# Altium schematic sheet SteppperController.SchDoc
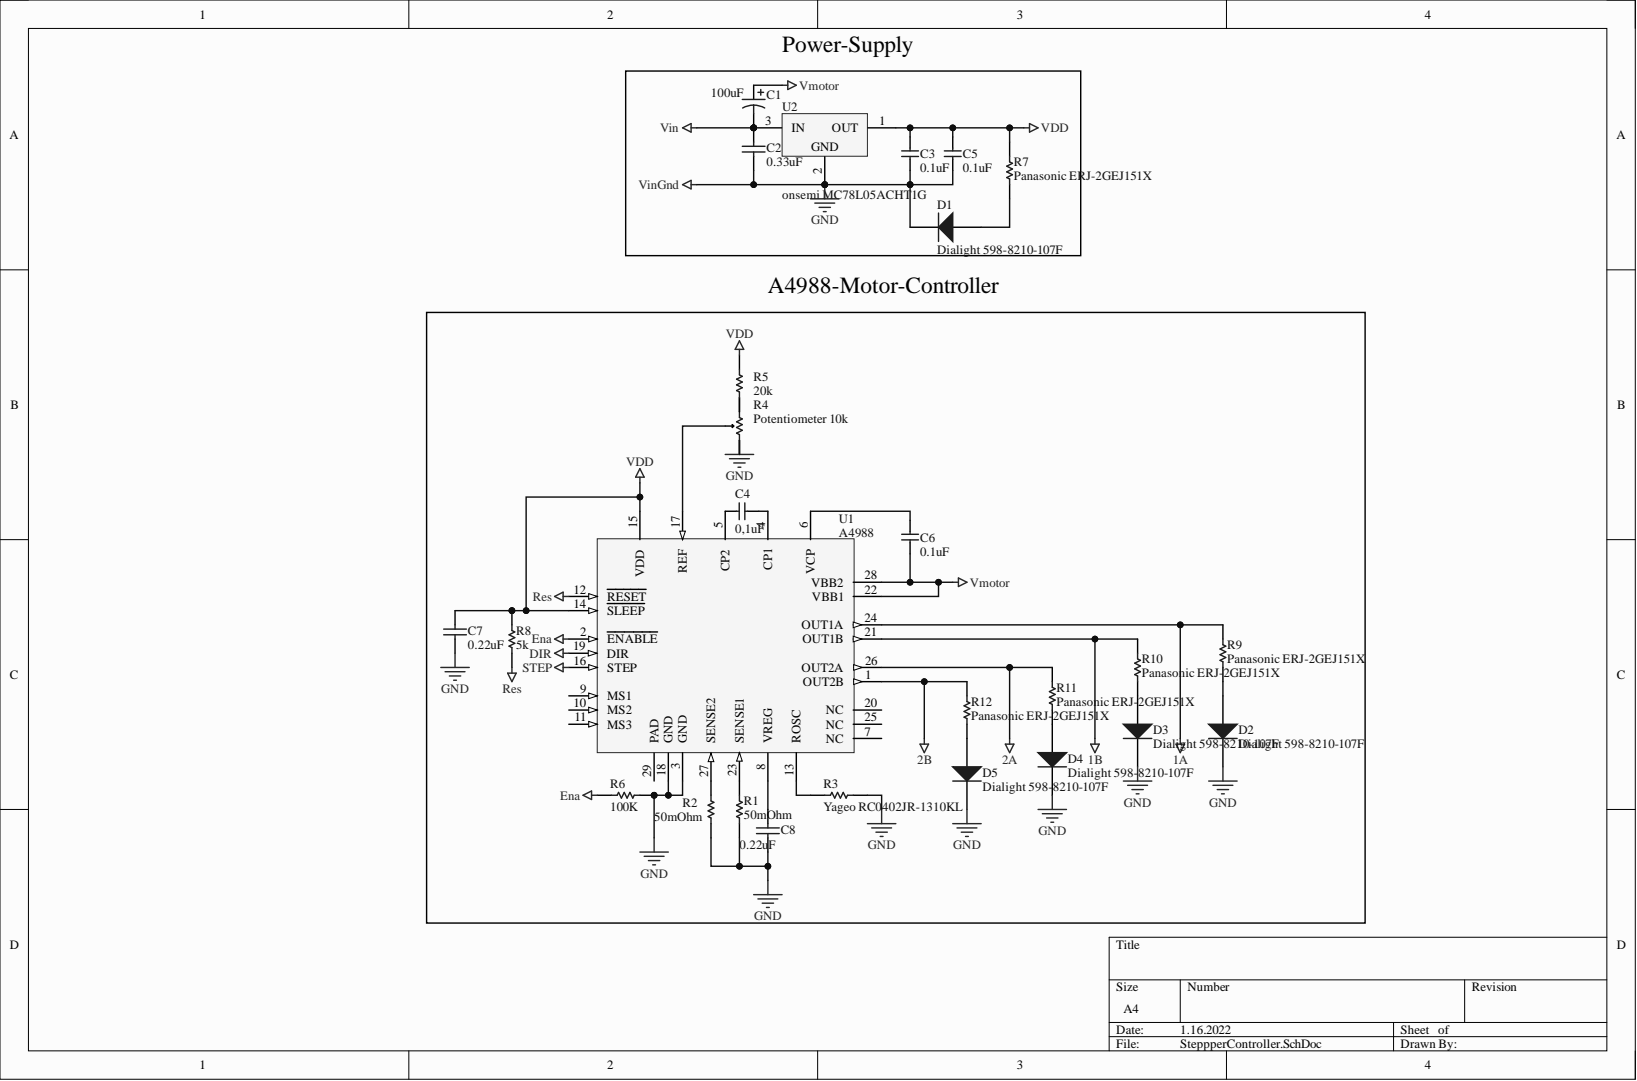
[diagram p0: SteppperController.SchDoc]

## Parts
C1  100uF  [PCB-i2lbf4zom8ks3vbq1kyd-1]
C2  0.33uF  [PCB-w610zufupuummbghd1in-1]
C3  0.1uF  [PCB-lcc7kuvv5qjd2xw4yurg-1]
C4  0,1uF  [PCB-lcc7kuvv5qjd2xw4yurg-1]
C5  0.1uF  [PCB-lcc7kuvv5qjd2xw4yurg-1]
C6  0.1uF  [PCB-lcc7kuvv5qjd2xw4yurg-1]
C7  0.22uF  [PCB-pms7qjbv2zfto0v29362-1]
C8  0.22uF  [PCB-pms7qjbv2zfto0v29362-1]
D1  Dialight 598-8210-107F  Manufacturer Part Number: 598-8210-107F  [PCB-nfeeyiqhjphw6gveeqew-1]
D2  Dialight 598-8210-107F  Manufacturer Part Number: 598-8210-107F  [PCB-nfeeyiqhjphw6gveeqew-1]
D3  Dialight 598-8210-107F  Manufacturer Part Number: 598-8210-107F  [PCB-nfeeyiqhjphw6gveeqew-1]
D4  Dialight 598-8210-107F  Manufacturer Part Number: 598-8210-107F  [PCB-nfeeyiqhjphw6gveeqew-1]
D5  Dialight 598-8210-107F  [PCB-nfeeyiqhjphw6gveeqew-1]
R1  50mOhm  [PCB-h38ewjcsmg51leo397rp-1]
R2  50mOhm  [PCB-h38ewjcsmg51leo397rp-1]
R3  Yageo RC0402JR-1310KL  Manufacturer Part Number: RC0402JR-1310KL  [RESC1005X40X25LL05T10]
R4  Potentiometer 10k  [PCB-obt52c5d0m95txgvi4qh-1]
R5  20k  [RESC2013X55X40LL15T20]
R6  100K  [PCB-n97kkldbbf5rklmnt71m-1]
R7  Panasonic ERJ-2GEJ151X  [RESC1005X40X25ML05T05]
R8  5k  [RESC1608X55X30NL20T20]
R9  Panasonic ERJ-2GEJ151X  Manufacturer Part Number: ERJ-2GEJ151X  [RESC1005X40X25ML05T05]
R10  Panasonic ERJ-2GEJ151X  Manufacturer Part Number: ERJ-2GEJ151X  [RESC1005X40X25ML05T05]
R11  Panasonic ERJ-2GEJ151X  Manufacturer Part Number: ERJ-2GEJ151X  [RESC1005X40X25ML05T05]
R12  Panasonic ERJ-2GEJ151X  [RESC1005X40X25ML05T05]
U1  A4988  [ALEG-ET-28_V]
U2  onsemi MC78L05ACHT1G  Manufacturer Part Number: MC78L05ACHT1G  [NXP-SOT89_A_M]

## Nets
1A: R9.2 U1.24(OUT1A)
1B: R10.2 U1.21(OUT1B)
2A: R11.2 U1.26(OUT2A)
2B: R12.2 U1.1(OUT2B)
DIR: U1.19(DIR)
Ena: R6.2 U1.2(ENABLE)
GND: C2.1 C3.1 C5.1 C7.1 C8.1 D1.2 D2.2 D3.2 D4.2 D5.2 R1.1 R2.1 R3.2 R4.3 R6.1 U1.3(GND) U1.18(GND) U2.2
Res: R8.1 U1.12(RESET)
STEP: U1.16(STEP)
VDD: C3.2 C5.2 C7.2 R5.2 R7.2 R8.2 U1.14(SLEEP) U1.15(VDD) U2.1
Vin: C1.2 C2.2 U2.3
Vmotor: C1.1 C6.1 U1.22(VBB1) U1.28(VBB2)
NetC4_1: C4.1 U1.5(CP2)
NetC4_2: C4.2 U1.4(CP1)
NetC6_2: C6.2 U1.6(VCP)
NetC8_2: C8.2 U1.8(VREG)
NetD1_1: D1.1 R7.1
NetD2_1: D2.1 R9.1
NetD3_1: D3.1 R10.1
NetD4_1: D4.1 R11.1
NetD5_1: D5.1 R12.1
NetR1_2: R1.2 U1.23(SENSE1)
NetR2_2: R2.2 U1.27(SENSE2)
NetR3_1: R3.1 U1.13(ROSC)
NetR4_1: R4.1 R5.1
NetR4_2: R4.2 U1.17(REF)

Not connected: U1 7(NC) 9(MS1) 10(MS2) 11(MS3) 20(NC) 25(NC) 29(PAD)

## Library data of the parts
C1 = CMP-3b00f20907dbe4c7-1: 100uF 25 V dc Aluminium Electrolytic Capacitor; WT Series 1000h 6.3(Dia.)x7.7mm; Manufacturer Part Number: UWT1E101MCL1GS; Capacitance: 100 µF; Capacitance Tolerance: ±20%; Dielectric Material: Aluminum Electrolytic; Lead-Free Status: Lead Free; Voltage Rating (DC): 25.0 V; Component Kind: Standard; Library Name: My Personal Space; Mounting Style: Surface Mount; Number of Pins: 2; Octopart URL: https://octopart.com/uwt1e101mcl1gs-nichicon-203197; OctopartID: 3b00f20907dbe4c7; Operating Temperature: -55.0 °C (min); Packaging: Tape & Reel (TR), Cut Tape (CT); RoHS: Compliant; Size-Diameter: 6.30 mm; Size-Height: 7.70 mm; Size-Length: 7.70 mm
C2 = CMP-6acba34cbf0cb50c-1: CL31 Series 0.33 uF 50 V ±10 % Tolerance X7R SMT Multilayer Ceramic Capacitor; Manufacturer Part Number: CL31B334KBFNNNE; Capacitance: 330 nF; Capacitance Tolerance: ±10%; Lead-Free Status: Lead Free; Voltage Rating (DC): 50.0 V; Case/Package: 1206; Component Kind: Standard; Dielectric Characteristic: X7R; Library Name: My Personal Space; Mounting Style: Surface Mount; Octopart URL: https://octopart.com/cl31b334kbfnnne-samsung-9302177; OctopartID: 6acba34cbf0cb50c; RoHS: Compliant
C3-C6 = CMP-915a59dc44ec4e9d-4: C Series 1206 0.1 uF 50 V ±5 % Tolerance C0G SMT Multilayer Ceramic Capacitor; Component Kind: Standard; Library Name: My Personal Space
C7 C8 = CMP-3551434-4: Multilayer Ceramic Capacitors MLCC - SMD/SMT 50volts .22uF 5% X7R 7inch reel; Manufacturer Part Number: 08055C224JAT2A; Capacitance: 2.2e-07 F; Lead-Free Status: Lead Free; Voltage Rating (DC): 50.0 V; Capacitance Tolerance: ±5%; Case/Package: 0805; Component Kind: Standard; Dielectric Characteristic: X7R; Library Name: My Personal Space; Mounting Style: Surface Mount; Number of Pins: 2; Octopart Url: https://octopart.com/08055c224jat2a-avx+interconnect+%2F+elco-8665279; OctopartID: 61bba8357c62be7c; RoHS: Compliant
D1-D5 = CMP-bfda76ddda44601d-2: LED Uni-Color Red 2-Pin Chip 1206(3216Metric) T/R; Case/Package (SI): 3216; Forward Voltage: 2.00 V; Lead-Free Status: Lead Free; Lens Type: Non-Diffused; Luminous Intensity: 80.0 mcd; Viewing Angle: 140 °; Wavelength: 635 nm, 640 nm; Case/Package: 1206; Color: Red; Component Kind: Standard; Lens Color: Red; Library Name: My Personal Space; Mounting Style: Surface Mount, Vertical; Number of Pins: 2; Octopart URL: https://octopart.com/598-8210-107f-dialight-868600; OctopartID: bfda76ddda44601d; Operating Temperature: 85.0 °C (max); Packaging: Cut Tape (CT); REACH SVHC Compliance: No SVHC; RoHS: Compliant; Size-Height: 700 µm; Size-Length: 3.20 mm; Size-Width: 1.40 mm
  D5: Manufacturer Part Number: 598-8210-107F
R1 R2 = CMP-2fd8e4750972f01f-1: Thick Film Surface Mnt Resistor 2512 Case 50MOhm +/-1% 1W +/-100ppm/degC; Manufacturer Part Number: CRHV2512AF50M0FKET; Component Kind: Standard; Library Name: My Personal Space; Octopart URL: http://octopart.com/crhv2512af50m0fket-vishay-44499916; OctopartID: 2fd8e4750972f01f; Packaging: Reel
R3 = CMP-3759243-2: YAGEO   RC0402JR-1310KL   THICK FILM RESISTOR, 10KOHM, 62.5mW, 5%; Power Rating: 0.0625 W; Resistance: 10000.0 Ω; Voltage Rating (DC): 50.0 V; Case/Package: 0402; Component Kind: Standard; Library Name: My Personal Space; Mounting Style: Surface Mount; Number of Pins: 2; Octopart Url: https://octopart.com/rc0402jr-1310kl-yageo-12819538; OctopartID: 7a6eb3a7c35aa5a9; Packaging: Cut Tape (CT); Resistance Tolerance: ±5%; RoHS: Compliant; Size-Length: 0.001 m
R4 = CMP-1577701-10: Res Conductive Plastic POT 10K Ohm 20% 3/100W 1Turn 6mm Pin Bracket Mount/Through Hole; Manufacturer Part Number: P090L-02F25BR10K; Lead-Free Status: Lead Free; Power Rating: 30.0 mW; Component Kind: Standard; Library Name: My Personal Space; Mounting Style: Through Hole, Board, PCB; Octopart URL: https://octopart.com/p090l-02f25br10k-bi+technologies-5427413; OctopartID: 7c74b52c89c7a3b3; Operating Temperature: 70.0 °C (max); Packaging: Tray; Resistance Tolerance: ±20%; RoHS: Compliant
R5 = CMP-15323555-2: Manufacturer Part Number: TNPW080520K0BEEA; Lead-Free Status: Lead Free; Power Rating: 0.125 W; Resistance: 20000.0 Ω; Resistance Tolerance: ±0.1%; Voltage Rating (DC): 100.0 V; Case/Package: 0805; Component Kind: Standard; Library Name: My Personal Space; Number of Pins: 2; Octopart Url: https://octopart.com/tnpw080520k0beea-vishay-46507859; OctopartID: 596b92a4db5e86ac; RoHS: Compliant
R6 = CMP-86ed4a8b7141592a-1: Res General Purpose Thick Film 0402 100k Ohm 1% 1/16W ±100ppm/°C Molded Paper T/R; Manufacturer Part Number: CRGCQ0402F100K; Power Rating: 63.0 mW; Resistance: 100 kΩ; Temperature Coefficient: -100 ppm/°C to 100 ppm/°C; Voltage Rating (DC): 50.0 V; Case/Package: 0402; Component Kind: Standard; Library Name: My Personal Space; Lifecycle Status: Not Listed by Manufacturer; Octopart URL: https://octopart.com/crgcq0402f100k-te+connectivity-91017930; OctopartID: 86ed4a8b7141592a; Packaging: Tape & Reel (TR); Resistance Tolerance: ±1%; RoHS: Compliant
R7 R9-R12 = CMP-3653280-2: Thick Film Resistors - SMD 0402 150ohms 5% Tol; Lead-Free Status: Lead Free; Power Rating: 0.1 W; Resistance: 150.0 Ω; Voltage Rating (DC): 50.0 V; Case/Package: 0402; Component Kind: Standard; Library Name: My Personal Space; Lifecycle Status: Not Listed by Manufacturer; Mounting Style: Surface Mount; Octopart Url: https://octopart.com/erj-2gej151x-panasonic-55412484; OctopartID: 1e2bd46cbc49c1ec; Packaging: Cut Tape (CT); REACH SVHC Compliance: No SVHC; Resistance Tolerance: ±5%; RoHS: Compliant; Size-Height: 0.00035 m; Size-Length: 0.001 m; Size-Width: 0.0005 m
  R7 R12: Manufacturer Part Number: ERJ-2GEJ151X
R8 = CMP-22beeaeef4a5fd79-1: Res Thin Film 0603 10K Ohm 0.5% 1/16W ±25ppm/°C Molded SMD SMD Paper T/R; Manufacturer Part Number: CPF0603D10KE; ELV Compliant: Compliant; Case/Package: 0603; Component Kind: Standard; Library Name: My Personal Space; Lifecycle Status: Active; Mounting Style: Surface Mount; Octopart Url: https://octopart.com/cpf0603d10ke-te+connectivity-22737789; OctopartID: 22beeaeef4a5fd79; Packaging: Tape & Reel (TR); RoHS: Compliant
U1 = CMP-966506-24: DMOS Microstepping Driver with Translator and Overcurrent Protection, 3 to 5.5 V, -20 to 85 degC, 28-Pin QFN (ET), RoHS, Tape and Reel; Component Kind: Standard; Library Name: My Personal Space
U2 = CMP-4fb3855e828b9074-8: Linear Voltage Regulator, 100 mA, 5 to 24 V, Positive Vout: 5.0 V; TJ = 0°C to +125°C; Lead-Free Status: Lead Free; Output Current: 100 mA; Component Kind: Standard; Library Name: My Personal Space; Lifecycle Status: Active; Octopart Url: https://octopart.com/mc78l05acht1g-onsemi-65529950; OctopartID: 4fb3855e828b9074; Operating Temperature: 0.00 °C (min); Packaging: Cut Tape (CT); RoHS: Compliant
